# Altium schematic sheet ESP32 CAN Datalogger.SchDoc("ESP32 CAN Datalogger")
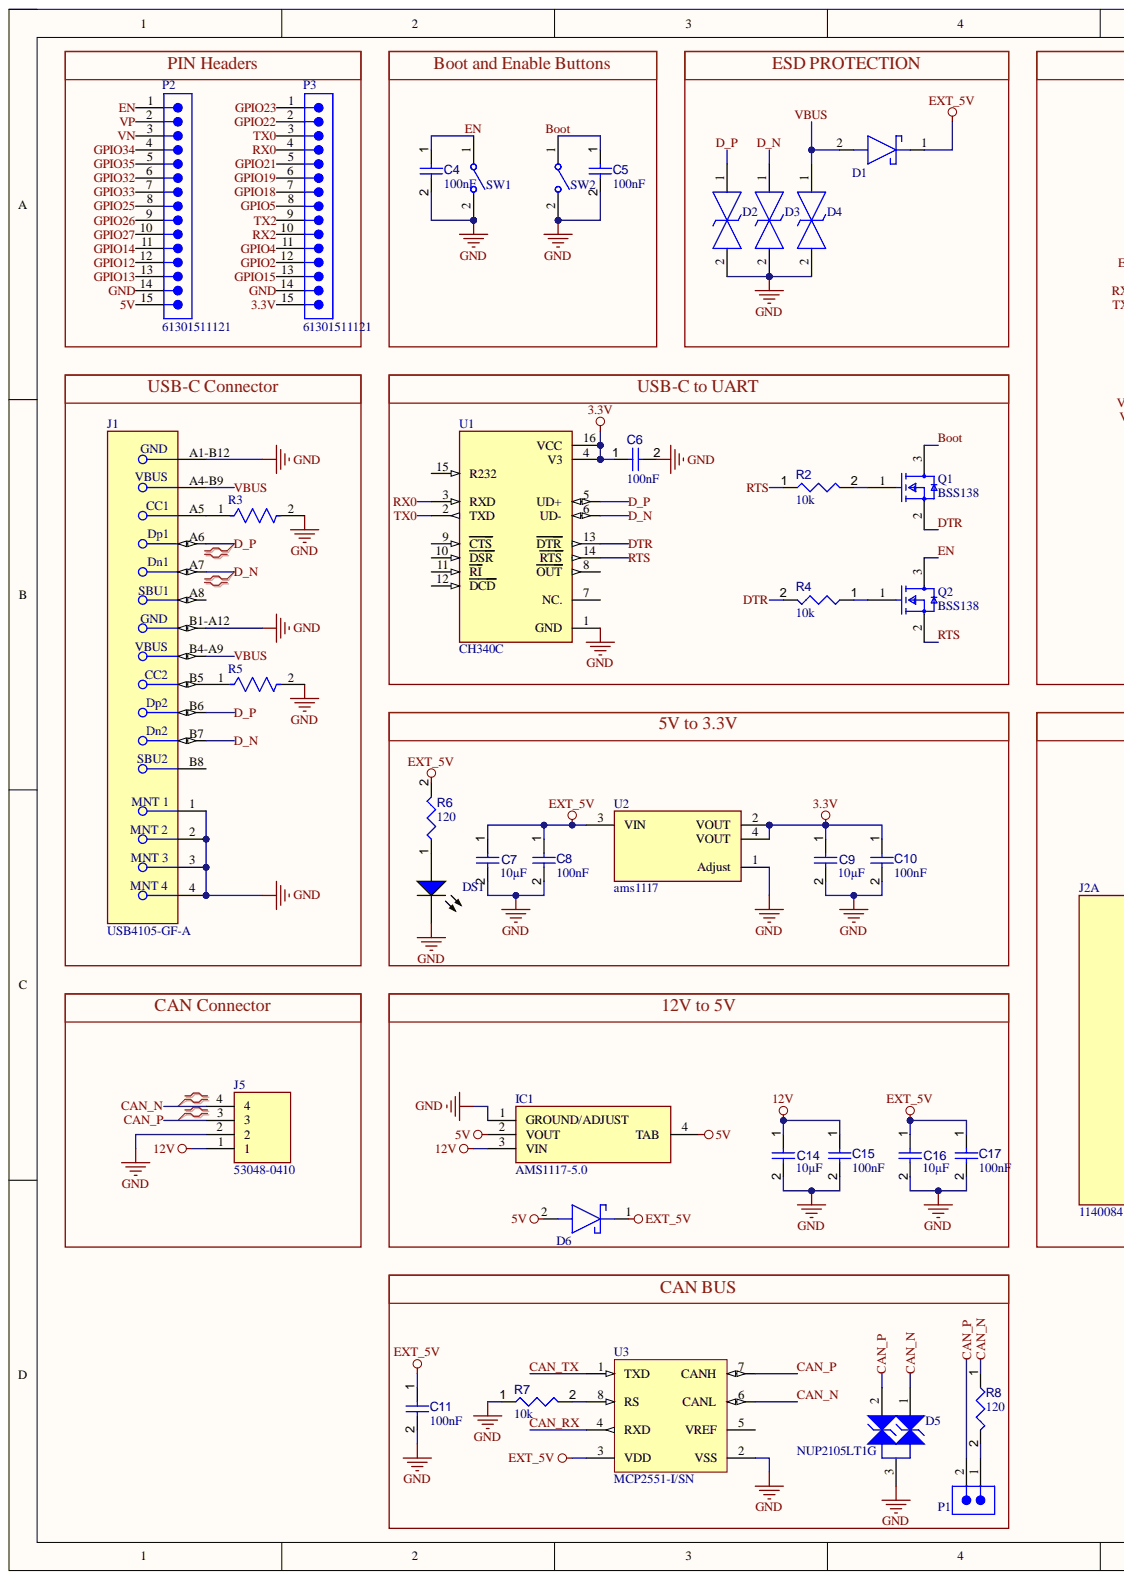
[diagram p0: ESP32 CAN Datalogger.SchDoc, left]
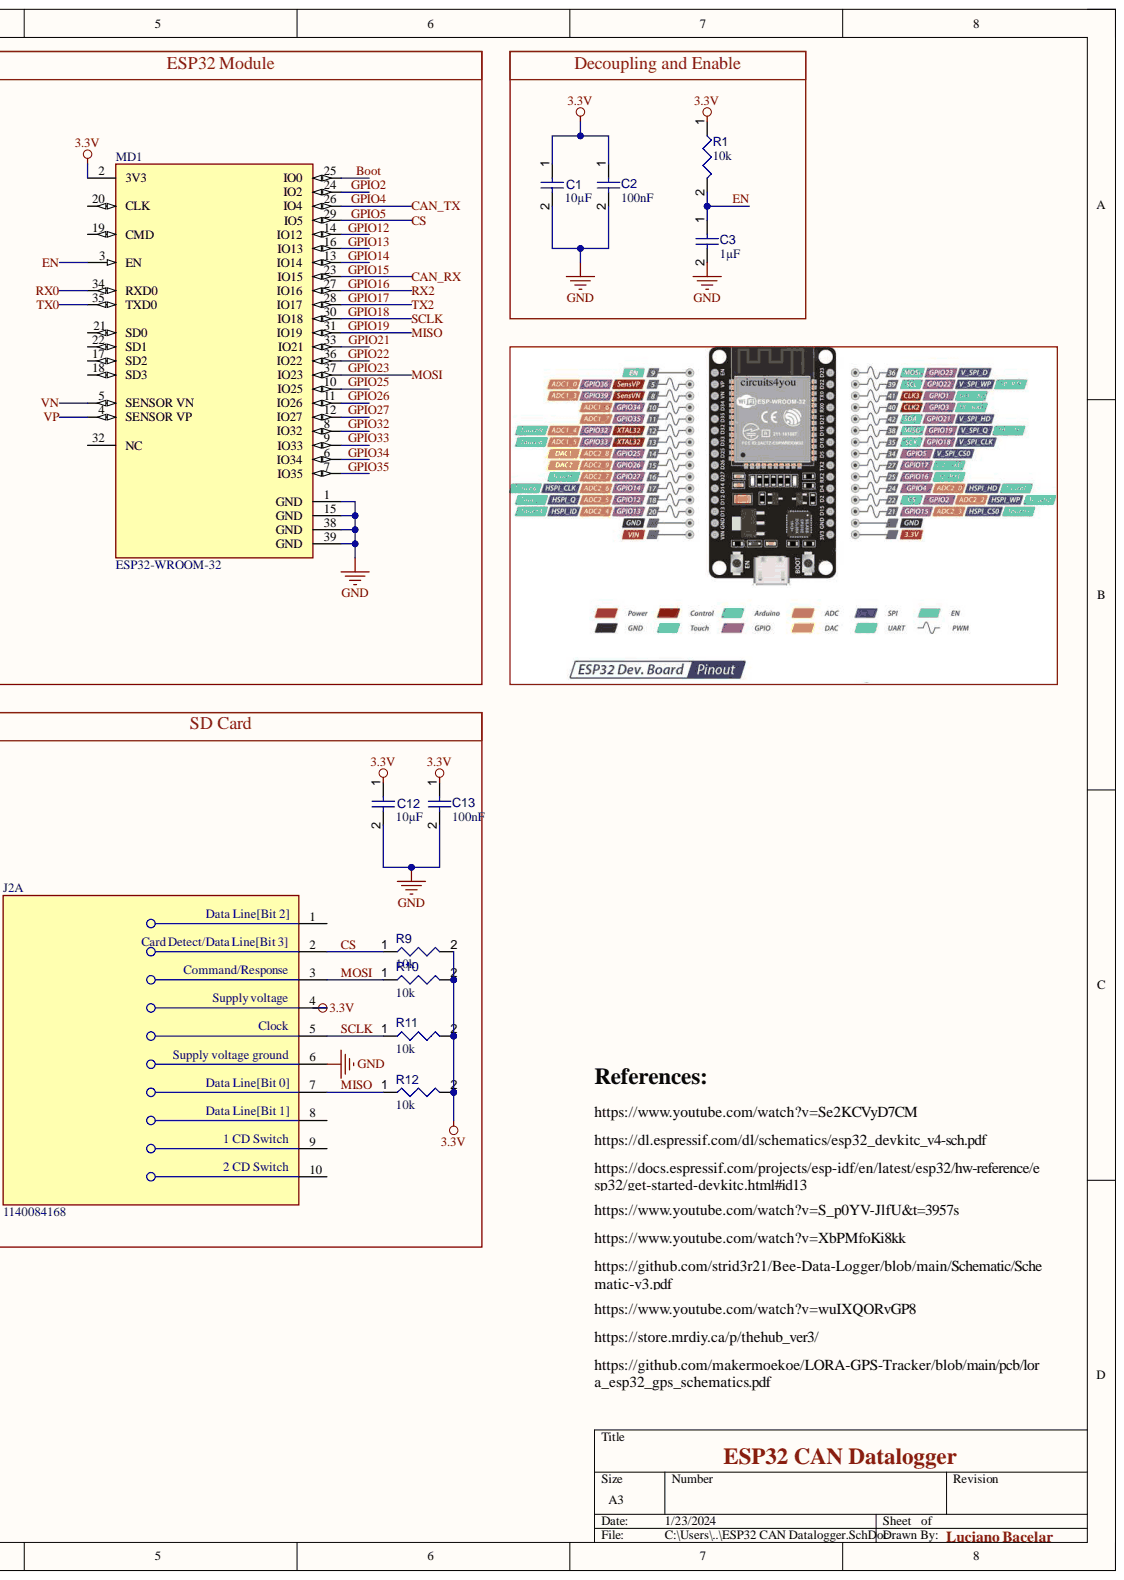
[diagram p1: ESP32 CAN Datalogger.SchDoc, right]

## Parts
C1  value 10µF  [CAPC0603(1608)100_L]  (hidden comment: Capacitor 10µF +/-20% 10V 0603)
C2  value 100nF  [CAPC1608X90X40NL10T20]  (hidden comment: Capacitor 100 nF +/-10% 50 V 0603)
C3  value 1µF  [CAPC1608X90X35ML15T15]  (hidden comment: Capacitor 1uF +/- 10% 16V 0603)
C4  value 100nF  [CAPC1608X90X40NL10T20]  (hidden comment: Capacitor 100 nF +/-10% 50 V 0603)
C5  value 100nF  [CAPC1608X90X40NL10T20]  (hidden comment: Capacitor 100 nF +/-10% 50 V 0603)
C6  value 100nF  [CAPC1608X90X40NL10T20]  (hidden comment: Capacitor 100 nF +/-10% 50 V 0603)
C7  value 10µF  [CAPC0603(1608)100_L]  (hidden comment: Capacitor 10µF +/-20% 10V 0603)
C8  value 100nF  [CAPC1608X90X40NL10T20]  (hidden comment: Capacitor 100 nF +/-10% 50 V 0603)
C9  value 10µF  [CAPC0603(1608)100_L]  (hidden comment: Capacitor 10µF +/-20% 10V 0603)
C10  value 100nF  [CAPC1608X90X40NL10T20]  (hidden comment: Capacitor 100 nF +/-10% 50 V 0603)
C11  value 100nF  [CAPC1608X90X40NL10T20]  (hidden comment: Capacitor 100 nF +/-10% 50 V 0603)
C12  value 10µF  [CAPC0603(1608)100_L]  (hidden comment: Capacitor 10µF +/-20% 10V 0603)
C13  value 100nF  [CAPC1608X90X40NL10T20]  (hidden comment: Capacitor 100 nF +/-10% 50 V 0603)
C14  value 10µF  [CAPC0603(1608)100_L]  (hidden comment: Capacitor 10µF +/-20% 10V 0603)
C15  value 100nF  [CAPC1608X90X40NL10T20]  (hidden comment: Capacitor 100 nF +/-10% 50 V 0603)
C16  value 10µF  [CAPC0603(1608)100_L]  (hidden comment: Capacitor 10µF +/-20% 10V 0603)
C17  value 100nF  [CAPC1608X90X40NL10T20]  (hidden comment: Capacitor 100 nF +/-10% 50 V 0603)
D1  BAT760-7  [SOD2513X120N]  (value not drawn)
D2  LESD5D5.0CT1G  [LESD5D50CT1G]  (value not drawn)
D3  LESD5D5.0CT1G  [LESD5D50CT1G]  (value not drawn)
D4  LESD5D5.0CT1G  [LESD5D50CT1G]  (value not drawn)
D5  NUP2105LT1G  [ONSC-SOT-23-3-318-08_V]
D6  BAT760-7  [SOD2513X120N]  (value not drawn)
DS1  TLMB1100-GS08  [VISH-TLMX1100-2_V]  (value not drawn)
IC1  AMS1117-5.0  [SOT229P700X180-4N]
J1  USB4105-GF-A  [FP-USB4105-GF-A-MFG]
J2  1140084168  [FP-1140084168-0]  (part A)
J5  53048-0410  [530480410]
MD1  ESP32-WROOM-32  [FP-ESP32-WROOM-32-MFG]
P1  62000211121  [62000211121]  (value not drawn)
P2  61301511121  [61301511121]
P3  61301511121  [61301511121]
Q1  BSS138  [FP-318-08-IPC_B]
Q2  BSS138  [FP-318-08-IPC_B]
R1  value 10k  [RESC1608X55X25ML10T15]  (hidden comment: Resistor 10k +/-1% 0603 100 mW)
R2  value 10k  [RESC1608X55X25ML10T15]  (hidden comment: Resistor 10k +/-1% 0603 100 mW)
R3  RG2012P-512-B-T5  [FP-RG2012-IPC_A]  (value not drawn)
R4  value 10k  [RESC1608X55X25ML10T15]  (hidden comment: Resistor 10k +/-1% 0603 100 mW)
R5  RG2012P-512-B-T5  [FP-RG2012-IPC_A]  (value not drawn)
R6  value 120  [RESC0603(1608)_L]  (hidden comment: Resistor 120R +/-5 % 0603 0.1 W)
R7  value 10k  [RESC1608X55X25ML10T15]  (hidden comment: Resistor 10k +/-1% 0603 100 mW)
R8  value 120  [RESC0603(1608)_L]  (hidden comment: Resistor 120R +/-5 % 0603 0.1 W)
R9  value 10k  [RESC1608X55X25ML10T15]  (hidden comment: Resistor 10k +/-1% 0603 100 mW)
R10  value 10k  [RESC1608X55X25ML10T15]  (hidden comment: Resistor 10k +/-1% 0603 100 mW)
R11  value 10k  [RESC1608X55X25ML10T15]  (hidden comment: Resistor 10k +/-1% 0603 100 mW)
R12  value 10k  [RESC1608X55X25ML10T15]  (hidden comment: Resistor 10k +/-1% 0603 100 mW)
SW1  434781025816  [WS-TASV_L6.2W4.1H2.5_4347x10258x6]  (value not drawn)
SW2  434781025816  [WS-TASV_L6.2W4.1H2.5_4347x10258x6]  (value not drawn)
U1  CH340C  [SOIC127P600X180-16N]
U2  ams1117  [FP-ams1117-IPC_C]
U3  MCP2551-I/SN  [MCHP-SN8_V]

## Nets
12V: C14.1 C15.1 IC1.3(VIN) J5.1
EXT_5V: C7.1 C8.1 C11.1 C16.1 C17.1 D1.1 D6.1 R6.2 U2.3(VIN) U3.3(VDD)
3.3V: C1.1 C2.1 C6.1 C9.1 C10.1 C12.1 C13.1 J2.4 MD1.2(3V3) P3.15 R1.1 R9.2 R10.2 R11.2 R12.2 U1.4(V3) U1.16(VCC) U2.2(VOUT) U2.4(VOUT)
5V: D6.2 IC1.2(VOUT) IC1.4(TAB) P2.15
Boot: C5.1 MD1.25(IO0) Q1.3 SW2.1
CAN_N: D5.1 J5.4 R8.1 U3.6(CANL)
CAN_P: D5.2 J5.3 P1.2 U3.7(CANH)
CAN_RX: MD1.23(IO15) P3.13 U3.4(RXD)
CAN_TX: MD1.26(IO4) P3.11 U3.1(TXD)
CS: J2.2 MD1.29(IO5) P3.8 R9.1
D_N: D3.1 J1.A7 J1.B7 U1.6(UD-)
D_P: D2.1 J1.A6 J1.B6 U1.5(UD+)
DTR: Q1.2 R4.2 U1.13(DTR)
EN: C3.1 C4.1 MD1.3(EN) P2.1 Q2.3 R1.2 SW1.1
GND: C1.2 C2.2 C3.2 C4.2 C5.2 C6.2 C7.2 C8.2 C9.2 C10.2 C11.2 C12.2 C13.2 C14.2 C15.2 C16.2 C17.2 D2.2 D3.2 D4.2 D5.3 DS1.2 IC1.1(GROUND/ADJUST) J1.1 J1.2 J1.3 J1.4 J1.A1-B12 J1.B1-A12 J2.6 J5.2 MD1.1(GND) MD1.15(GND) MD1.38(GND) MD1.39(GND) P2.14 P3.14 R3.2 R5.2 R7.1 SW1.2 SW2.2 U1.1(GND) U2.1(Adjust) U3.2(VSS)
GPIO2: MD1.24(IO2) P3.12
GPIO12: MD1.14(IO12) P2.12
GPIO13: MD1.16(IO13) P2.13
GPIO14: MD1.13(IO14) P2.11
GPIO16: MD1.27(IO16) P3.10
GPIO17: MD1.28(IO17) P3.9
GPIO18: J2.5 MD1.30(IO18) P3.7 R11.1
GPIO19: J2.7 MD1.31(IO19) P3.6 R12.1
GPIO21: MD1.33(IO21) P3.5
GPIO22: MD1.36(IO22) P3.2
GPIO23: J2.3 MD1.37(IO23) P3.1 R10.1
GPIO25: MD1.10(IO25) P2.8
GPIO26: MD1.11(IO26) P2.9
GPIO27: MD1.12(IO27) P2.10
GPIO32: MD1.8(IO32) P2.6
GPIO33: MD1.9(IO33) P2.7
GPIO34: MD1.6(IO34) P2.4
GPIO35: MD1.7(IO35) P2.5
RTS: Q2.2 R2.1 U1.14(RTS)
RX0: MD1.34(RXD0) P3.4 U1.3(RXD)
TX0: MD1.35(TXD0) P3.3 U1.2(TXD)
VBUS: D1.2 D4.1 J1.A4-B9 J1.B4-A9
VN: MD1.5(SENSOR_VN) P2.3
VP: MD1.4(SENSOR_VP) P2.2
NetDS1_1: DS1.1 R6.1
NetJ1_A5: J1.A5 R3.1
NetJ1_B5: J1.B5 R5.1
NetP1_1: P1.1 R8.2
NetQ1_1: Q1.1 R2.2
NetQ2_1: Q2.1 R4.1
NetR7_2: R7.2 U3.8(RS)

Not connected: J1 A8 B8; J2 1 8 9 10; MD1 17(SD2) 18(SD3) 19(CMD) 20(CLK) 21(SD0) 22(SD1) 32(NC); U1 7(NC.) 8(OUT) 9(CTS) 10(DSR) 11(RI) 12(DCD) 15(R232); U3 5(VREF)

## Library data of the parts
C1 C7 C9 C12 C14 C16 = CMP-001-00075-4: Chip Capacitor, 10µF +/-20%, 10V, 0603, Thickness 1 mm; Tolerance: 10%; Case/Package: 0603; Component Kind: Standard; Max Operating Temperature: 85°C; Min Operating Temperature: -55°C; Mounting Technology: SMT; Pins: 2; Voltage Rating: 10V; Library Name: Luciano Bacelar
C2 C4-C6 C8 C10 C11 C13 C15 C17 = CMP-001-00017-6: Chip Capacitor, 100 nF, +/- 10%, 50 V, 0603 (1608 Metric); Capacitor Type: Ceramic; Voltage Rating: 50V; Case/Package: 0603; Component Kind: Standard; Max Operating Temperature: 125°C; Min Operating Temperature: -55°C; Mounting Technology: SM; Pins: 2; RoHS Compliant: True; Tolerance: 10%; Library Name: Luciano Bacelar
C3 = CMP-001-00004-6: Chip Capacitor, 1 uF, +/- 10%, 16 V, 0603 (1608 Metric); Capacitor Type: Ceramic; Case/Package: 0603; Component Kind: Standard; Max Operating Temperature: 125°C; Min Operating Temperature: -55°C; Mounting Technology: SM; Pins: 2; RoHS Compliant: True; Tolerance: 10%; Voltage Rating: 16V; Library Name: Luciano Bacelar
D1 D6 = BAT760-7: Schottky Diode; Manufacturer_Name: Diodes Incorporated; Arrow Part Number: BAT760-7; Arrow Price/Stock: https://www.arrow.com/en/products/bat760-7/diodes-incorporated?region=nac; Component Kind: Standard; Datasheet Link: https://www.diodes.com/assets/Datasheets/ds30498.pdf; Height: 1.2mm; Manufacturer_Part_Number: BAT760-7; Mouser Part Number: 621-BAT760-7; Mouser Price/Stock: https://www.mouser.co.uk/ProductDetail/Diodes-Incorporated/BAT760-7?qs=JV7lzlMm3yKDDyO09xoRbQ%3D%3D
D2-D4 = LESD5D5.0CT1G: TVS Diode (Bi-directional); Manufacturer_Name: LRC; Component Kind: Standard; Height: 0.7mm; Manufacturer_Part_Number: LESD5D5.0CT1G
D5 = CMP-1063-00164-1: Dual Line CAN Bus Protector, 3-Pin SOT-23, Pb-Free, Tape and Reel; Manufacturer: On Semiconductor; C Max (pF): 30; Direction: Bidirectional; IR Max (uA): 0.1; Number of Lines: 2; PPK Max (W): 350; V(BR) Min (V): 26.2; Component Kind: Standard; ComponentLink1Description: Manufacturer URL; ComponentLink1URL: http://www.onsemi.com/; ComponentLink2Description: Datasheet; ComponentLink2URL: http://www.onsemi.cn/pub_link/Collateral/NUP2105L-D.PDF; ComponentLink3Description: Package Specification; ComponentLink3URL: http://www.onsemi.cn/pub_link/Collateral/318-08.PDF; DatasheetVersion: Rev. 5; Library Name: Altium Content Vault; Mounting Technology: Surface Mount; PackageDescription: 3-Pin Small Outline Transistor, Body 2.92 x 1.3 mm, Pitch 0.95 mm; PackageReference: SOT-23-3-318-08; PackageVersion: Rev. AP, 11/2009; Packing: Tape and Reel; PartNumber: NUP2105LT1G; RoHS: Pb-Free
DS1 = CMP-007-00028-2: Blue LED, 10 mA, 3.9 V, -40 to 100 degC, 2-Pin SMD (0603), RoHS, Tape and Reel; Manufacturer: Vishay Semiconductor; Forward Current: 10mA; Forward Voltage: 3.9V; Lens Style: Diffused; Luminous Intensity: 5mcd; Power: 68mW; Viewing Angle: 160degrees; Case/Package: 0603; Colour: Blue; Component Kind: Standard; Datasheet URL: http://download.siliconexpert.com/pdfs/2016/8/7/12/43/26/667/vsh_/manual/379263299541529tlmb1100.pdf; Datasheet Version: Rev. 2.7, 03/2016; Illumination Colour: Blue; Manufacturer URL: http://www.vishay.com/; Max Operating Temperature: 100°C; Min Operating Temperature: -40°C; Mounting Technology: SM; Nominal Vf: 3.9V; Package Description: 2-Pin Surface Mount Device, Body 1.6 x 0.8 mm; Package Quantity: 3000; Package Reference: 0603; Packaging: TapeandReel; Pins: 2; RoHS Compliant: True; Library Name: Luciano Bacelar
IC1 = AMS1117-5.0: Integrated Circuit; Manufacturer_Name: Advanced Monolithic Systems; Component Kind: Standard; Datasheet Link: http://www.advanced-monolithic.com/pdf/ds1117.pdf; Height: 1.8mm; Manufacturer_Part_Number: AMS1117-5.0
J1 = CMP-245163-000001-1: CONN RCPT USB2.0 TYPEC 5A; Manufacturer: GCT; Conn Orientation: Right Angle; Current Rating: 5/6.25/1.25/0.25A; Is Female: yes; Number Of Contacts: 16; Voltage Rating: 20V; Automotive: No; Category: Conn; Component Kind: Standard; ComponentLink2Description: Datasheet; ComponentLink2URL: https://gct.co/files/drawings/usb4105.pdf; Contact Material: Gold,Nickel; Device Class L1: Connectors; Device Class L2: USB Connectors; Device Class L3: unset; Digikey Description: CONN RCPT USB2.0 TYPEC 5A; Height: 3.46mm; Lead Free: Yes; Library Name: Altium Content Vault; Manufacturer Part Number: USB4105-GF-A; Octopart: https://octopart.com/usb4105-gf-a-gct-106627878; Package: 0; RoHS: TRUE; Temperature: -40°C to +85°C; Temperature Range High: +85°C; Temperature Range Low: -40°C; Usb Standard: USB 2.0
J2 = CMP-02360-000003-1: CONN MICRO SD CARD PUSH-PULL R/A; Connector Type: Connector; Gender: Receptacle; Number of Contacts: 8; Pitch: 1.1mm; Component Kind: Standard; Contact Material: Copper Alloy; Contact Plating: Gold; Depth: 11.45mm; Flammability Rating: UL94 V-0; Height: 1.55mm; Housing Material: Polymer; Lead Free: Lead Free; Length: 15.6mm; Library Name: Altium Content Vault; Mount: Surface Mount; Number of Rows: 1; Orientation: Right Angle; Package Quantity: 7500; Packaging: Tape and Reel; Radiation Hardening: No; Termination: SMD/SMT
J5 = 53048-0410: Connector; Manufacturer_Name: Molex; Component Kind: Standard; Datasheet Link: https://www.molex.com/pdm_docs/sd/530480410_sd.pdf; Height: 3.7mm; Manufacturer_Part_Number: 53048-0410; Mouser Part Number: 538-53048-0410; Mouser Price/Stock: https://www.mouser.co.uk/ProductDetail/Molex/53048-0410?qs=359VwiUTsp2msj5Tobchuw%3D%3D
MD1 = CMP-194065-000008-1: RX TXRX MOD WIFI SURFACE MOUNT; Data Rate: 150Mbps; Frequency: 2.5GHz; Operating Supply Voltage: 3.6V; Sensitivity: -98dBm; Case/Package: SMD/SMT; Component Kind: Standard; Library Name: Altium Content Vault; Max Operating Temperature: 85°C; Min Operating Temperature: -40°C; Mount: Surface Mount; Package Quantity: 550; Packaging: Cut Tape; Weight: 0.088185oz
P1 = CMP-1502-00956-3: NexarPartId: 61924778; Contact Resistance: 20mΩ; Current Rating: 2A; Gender: Male; Number of Contacts: 2; Operating Supply Voltage: 200V; Component Kind: Standard; Contact Material: Gold; Height: 6.35mm; Lead Pitch: 2mm; Library Name: Altium Content Vault; Max Operating Temperature: 105°C; Min Operating Temperature: -40°C; Number of Pins: 2; Number of Rows: 1; Orientation: Vertical; RoHS: Non-Compliant; Voltage Rating: 200V
P2 P3 = CMP-207987-000006-2: CONN HEADER VERT 15POS 2.54MM; NexarPartId: 32855546; Connector Type: Male Pin; Current Rating: 3A; Gender: Male; Number of Contacts: 15; Voltage Rating: 250V; Color: Black; Component Kind: Standard; Contact Material: Copper; Contact Mating Length: 5.9944mm; Contact Plating: Gold; Height: 8.89mm; Library Name: Altium Content Vault; Max Operating Temperature: 105°C; Min Operating Temperature: -40°C; Mount: Through Hole; Number of Positions: 15; Number of Rows: 1; Packaging: Bulk; Pitch: 2.54mm; REACH SVHC: Unknown; RoHS: Compliant; Termination: Solder
Q1 Q2 = CMP-07173-000101-1: MOSFET N-CH 50V 220MA SOT-23; Drain to Source Resistance: 3.5R; Element Configuration: Single; Fall Time: 9ns; Gate to Source Voltage (Vgs): 20V; Input Capacitance: 27pF; Max Power Dissipation: 360mW; Nominal Vgs: 1.3V; Number of Elements: 1; On-State Resistance: 6R; Turn-Off Delay Time: 20ns; Turn-On Delay Time: 2.5ns; Case/Package: SOT-23; Component Kind: Standard; Contact Plating: Tin; Continuous Drain Current (ID): 220mA; Current Rating: 220mA; Drain to Source Breakdown Voltage: 50V; Drain to Source Voltage (Vdss): 50V; Dual Supply Voltage: 50V; Height: 0.93mm; Lead Free: Lead Free; Length: 2.92mm; Library Name: Altium Content Vault; Max Operating Temperature: 150°C; Min Operating Temperature: -55°C; Mount: Surface Mount; Number of Pins: 3; Package Quantity: 1; Packaging: Tape and Reel; Power Dissipation: 360mW; Radiation Hardening: No; Rds On Max: 3.5R; REACH SVHC: No SVHC; Rise Time: 9ns; Termination: SMD/SMT; Threshold Voltage: 1.3V; Voltage Rating (DC): 50V; Weight: 0.03g; Width: 1.3mm
R1 R2 R4 R7 R9-R12 = CMP-009-00017-5: Chip Resistor, 10 KOhm, +/- 1%, 01 W, -55 to 155 degC, 0603 (1608 Metric); Power: 100mW; Voltage Rating: 50V; Case/Package: 0603; Component Kind: Standard; Max Operating Temperature: 155°C; Min Operating Temperature: -55°C; Mounting Technology: SM; Pins: 2; RoHS Compliant: True; Tolerance: 1%; Library Name: Luciano Bacelar
R3 R5 = CMP-2001-03010-2: Automotive Metal Thin Film Chip Resistor, 0805, 5.1kΩ, 0.1%, 25ppm/°C, 0.125W, 150V; Max Power Dissipation: 125mW; Power Rating: 100mW; Resistance: 5.1kR; Voltage Rating: 100V; Case Code (Imperial): 0805; Case Code (Metric): 2012; Case/Package: 0805; Component Kind: Standard; Features: Automotive AEC-Q200; Height: 0.02inch; Lead Free: Lead Free; Length: 0.079inch; Library Name: Altium Content Vault; Max Operating Temperature: 155°C; Min Operating Temperature: -55°C; Number of Terminations: 2; Package Quantity: 1; Packaging: Tape and Reel; Resistor Type: Thin Film; RoHS Compliant: Yes; Series: RG; Temperature Coefficient: 25ppm/°C; Termination: SMD/SMT; Tolerance: 0.1%; Voltage Rating (DC): 150V; Width: 0.049inch
R6 R8 = CMP-009-00176-3: Chip Resistor, 120 Ohms, +/-5 %, 0.1 W, -55 to 155 degC, 0603; Power: 100mW; Tolerance: 5%; Case/Package: 0603; Component Kind: Standard; Max Operating Temperature: 155°C; Min Operating Temperature: -55°C; Pins: 2; Library Name: Luciano Bacelar
SW1 SW2 = CMP-03913-118042302-1: 434781025816 Tactile Switch, Spst-no, 0.05A, 12V, SMD;; Current Rating: 50mA; Insulation Resistance: 100MΩ; Component Kind: Standard; Contact Plating: Silver; Height: 2.5mm; Library Name: Altium Content Vault; Max Operating Temperature: 85°C; Min Operating Temperature: -40°C; NexarPartId: 118042302; Packaging: Tape and Reel; RoHS: Compliant; Voltage Rating: 12V
U1 = CMP-003-000000-1: USB to serial chip CH340; MF: WCH; Availability: Not in stock; Check_prices: https://www.snapeda.com/parts/CH340C/WCH/view-part/?ref=eda; Component Kind: Standard; Component Type: Standard; Design Item ID: CH340C; MP: CH340C; Package: None; Pin Count: 16; Price: None; SnapEDA_Link: https://www.snapeda.com/parts/CH340C/WCH/view-part/?ref=snap; Source: CH340C.SchLib; Library Name: Luciano Bacelar
U2 = CMP-209535-000002-1: 1A LOW DROPOUT VOLTAGE REGULATOR; Component Kind: Standard; Library Name: Altium Content Vault; RoHS Compliant: Yes
U3 = CMP-0186-00138-3: High-Speed CAN Transceiver,   1 Mb/s, 4.5 to 5.5 V, 8-Pin SOIC (SN), Industrial Temperature, RoHS, Tube; Manufacturer: Microchip; Component Kind: Standard; ComponentLink1Description: Manufacturer URL; ComponentLink1URL: http://www.microchip.com/; ComponentLink2Description: Datasheet; ComponentLink2URL: http://ww1.microchip.com/downloads/en/DeviceDoc/21667f.pdf; ComponentLink3Description: Package Specification; ComponentLink3URL: http://www.microchip.com/stellent/groups/techpub_sg/documents/packagingspec/en012702.pdf; DatasheetVersion: Rev. F, 07/2010; Library Name: Altium Content Vault; Mounting Technology: Surface Mount; PackageDescription: 8-Pin Small Outline Integrated Circuit, Body 4.9 x 3.9 mm, Pitch 1.27 mm; PackageReference: SN8; RoHSCompliant: true
